# Altium schematic sheet Battery Management System.SchDoc("Battery Management System")
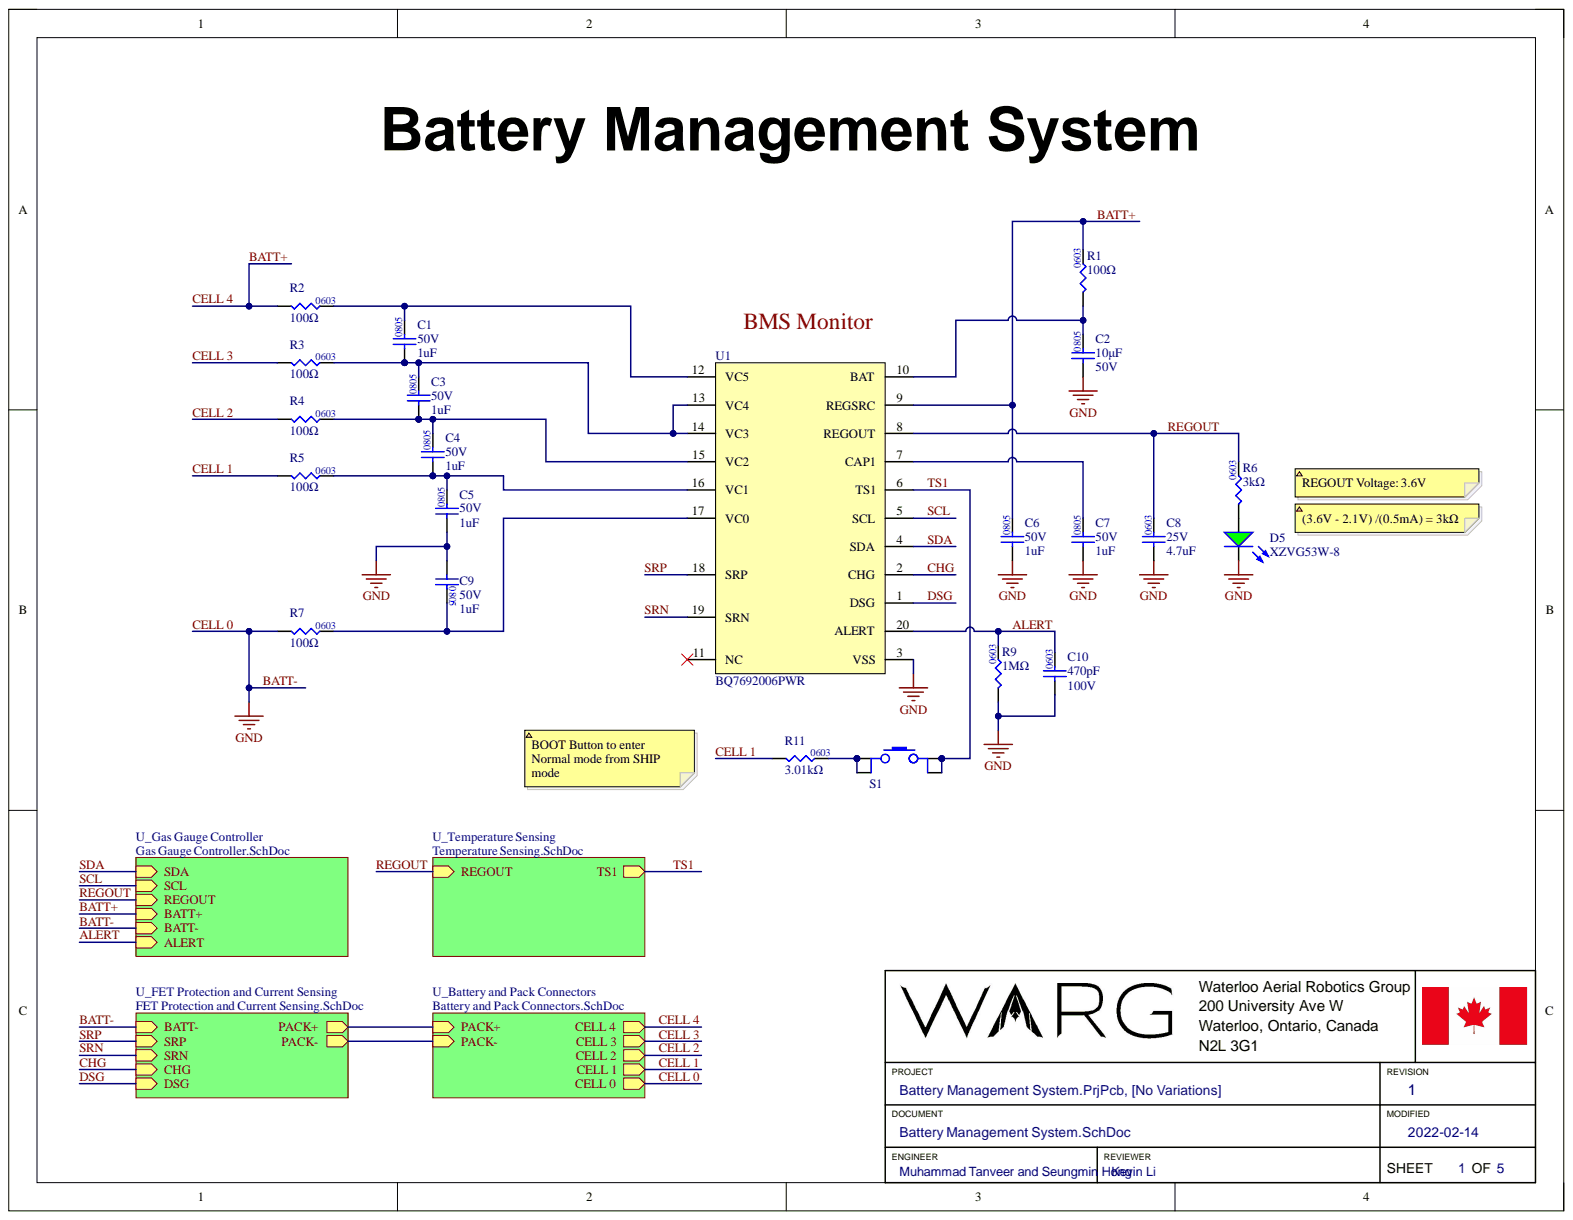
[diagram p0: Battery Management System.SchDoc]

## Parts
C1  value 1uF  Package: 0805  Voltage: 50V  [CAP0805]  (hidden comment: CAP_1uF_50V_0805)
C2  value 10μF  Package: 0805  Voltage: 50V  [CAP0805]  (hidden comment: CAP_10uF_50V_0805)
C3  value 1uF  Package: 0805  Voltage: 50V  [CAP0805]  (hidden comment: CAP_1uF_50V_0805)
C4  value 1uF  Package: 0805  Voltage: 50V  [CAP0805]  (hidden comment: CAP_1uF_50V_0805)
C5  value 1uF  Package: 0805  Voltage: 50V  [CAP0805]  (hidden comment: CAP_1uF_50V_0805)
C6  value 1uF  Package: 0805  Voltage: 50V  [CAP0805]  (hidden comment: CAP_1uF_50V_0805)
C7  value 1uF  Package: 0805  Voltage: 50V  [CAP0805]  (hidden comment: CAP_1uF_50V_0805)
C8  value 4.7uF  Package: 0603  Voltage: 25V  [CAP0603]  (hidden comment: CAP_4.7uF_25V_0603)
C9  value 1uF  Package: 0805  Voltage: 50V  [CAP0805]  (hidden comment: CAP_1uF_50V_0805)
C10  value 470pF  Package: 0603  Voltage Rating: 100V  [CAP0603]  (hidden comment: CAP_470pF_100V_0603)
D5  Manufacturer Part Number 1: XZVG53W-8  [LED0603]  (hidden comment: LED_GREEN_2.1V_0603)
R1  value 100Ω  Package: 0603  [RES0603]  (hidden comment: RES_0Ω_0603)
R2  value 100Ω  Package: 0603  [RES0603]  (hidden comment: RES_0Ω_0603)
R3  value 100Ω  Package: 0603  [RES0603]  (hidden comment: RES_0Ω_0603)
R4  value 100Ω  Package: 0603  [RES0603]  (hidden comment: RES_0Ω_0603)
R5  value 100Ω  Package: 0603  [RES0603]  (hidden comment: RES_0Ω_0603)
R6  Package: 0603  Resistance: 3kΩ  [RES0603]  (hidden comment: RES_3kΩ_0603_1%)
R7  value 100Ω  Package: 0603  [RES0603]  (hidden comment: RES_0Ω_0603)
R9  value 1MΩ  Package: 0603  [RES0603]  (hidden comment: RES_1MΩ_0603_1%)
R11  Package: 0603  Resistance: 3.01kΩ  [RES0603]  (hidden comment: RES_3.01kΩ_0603_1%)
S1  SWITCH_SPST_NO  [TL3301NF160QG/TR]  (value not drawn)
U1  BQ7692006PWR  [BQ7692006PWR]

## Nets
ALERT: C10.2 R9.2 U1.20(ALERT)
BATT+: C6.2 R1.2 R2.1 U1.9(REGSRC)
BATT-: C2.1 C5.1 C6.1 C7.1 C8.1 C9.1 C10.1 D5.2 R7.1 R9.1 U1.3(VSS)
CELL 1: R5.1 R11.1
CELL 2: R4.1
CELL 3: R3.1
CHG: U1.2(CHG)
DSG: U1.1(DSG)
REGOUT: C8.2 R6.2 U1.8(REGOUT)
SCL: U1.5(SCL)
SDA: U1.4(SDA)
SRN: U1.19(SRN)
SRP: U1.18(SRP)
TS1: S1.3 S1.4 U1.6(TS1)
NetC1_1: C1.1 C3.2 R3.2 U1.13(VC4) U1.14(VC3)
NetC1_2: C1.2 R2.2 U1.12(VC5)
NetC2_2: C2.2 R1.1 U1.10(BAT)
NetC3_1: C3.1 C4.2 R4.2 U1.15(VC2)
NetC4_1: C4.1 C5.2 R5.2 U1.16(VC1)
NetC7_2: C7.2 U1.7(CAP1)
NetC9_2: C9.2 R7.2 U1.17(VC0)
NetD5_1: D5.1 R6.1
NetR11_2: R11.2 S1.1 S1.2
NetU1_11: U1.11(NC)
PACK+:
PACK-:

## Library data of the parts
C1 C3-C7 C9 = CAP_1uF_50V_0805_MURATA: CAP CER 1UF 50V X7R ±10% 0805; Manufacturer 1: Murata Electronics; Manufacturer Part Number 1: GCJ21BR71H105KA01L; Component Kind: Standard; Mounting Type: Surface Mount; Supplier 1: Digi-Key; Supplier Part Number 1: 490-10667-1-ND; Temperature Coefficient: X7R; Tolerance: ±10%; Library Name: WARG.SchLib
C2 = CAP_10uF_50V_0805_MURATA: CAP CER 10UF 50V X5R ±10% 0805; Manufacturer 1: Murata Electronics; Manufacturer Part Number 1: GRM21BR61H106KE43L; Component Kind: Standard; LCSC Part #: C440198; Mounting Type: Surface Mount; Supplier 1: LCSC; Supplier 2: Digi-Key; Supplier Part Number 1: C440198; Supplier Part Number 2: 490-18663-1-ND; Temperature Coefficient: X5R; Tolerance: ±10%; Library Name: WARG.SchLib
C8 = CAP_4.7uF_25V_0603_MURATA: CAP CER 4.7UF 25V X6S ±10% 0603; Manufacturer 1: Murata Electronics; Manufacturer Part Number 1: GRM188C81E475KE11D; Component Kind: Standard; Mounting Type: Surface Mount; Supplier 1: Digi-Key; Supplier Part Number 1: 490-7199-1-ND; Temperature Coefficient: X6S; Tolerance: ±10%; Library Name: WARG.SchLib
C10 = CAP_470pF_100V_0603_MURATA: CAP CER 470PF 100V C0G/NP0 ±5% 0603; Manufacturer 1: Murata Electronics; Manufacturer Part Number 1: GCM1885C2A471JA16D; Component Kind: Standard; Mounting Type: Surface Mount; Supplier 1: Digi-Key; Supplier Part Number 1: 490-4949-1-ND; Temperature Coefficient: C0G/NP0; Tolerance: ±5%; Library Name: WARG.SchLib
D5 = LED_GREEN_2.1V_0603: LED GREEN 2.1V 0603; Manufacturer 1: SunLED; Color: Green; Component Kind: Standard; Forward Voltage: 2.1V; Mounting Type: Surface Mount; Package: 0603; Supplier 1: Digi-Key; Supplier Part Number 1: 1497-1215-1-ND; Library Name: WARG.SchLib
R1-R5 R7 = RES_100Ω_0603: RES 100 OHM 5% 1/10W 0603; Manufacturer 1: YAGEO; Manufacturer Part Number 1: RC0603JR-13100RL; Component Kind: Standard; Mounting Type: Surface Mount; Power Rating: 1/10W; Supplier 1: Digi-Key; Supplier Part Number 1: 13-RC0603JR-13100RLCT-ND; Library Name: WARG.SchLib
R6 = RES_3kΩ_0603_1%: RES 3K OHM 1% 1/10W 0603; Manufacturer 1: YAGEO; Manufacturer Part Number 1: RC0603FR-073KL; Component Kind: Standard; Mounting Type: Surface Mount; Power Rating: 1/10W; Supplier 1: Digi-Key; Supplier Part Number 1: 311-3.00KHRCT-ND; Tolerance: ±1%; Library Name: WARG.SchLib
R9 = RES_1MΩ_0603_5%: RES 1M OHM 5% 1/10W 0603; Manufacturer 1: Stackpole Electronics Inc; Manufacturer Part Number 1: RMCF0603JT1M00; Component Kind: Standard; Mounting Type: Surface Mount; Power Rating: 1/10W; Supplier 1: Digi-Key; Supplier Part Number 1: RMCF0603JT1M00CT-ND; Tolerance: ±5%; Library Name: WARG.SchLib
R11 = RES_3.01kΩ_0603_1%: RES 3.01K OHM 1% 1/10W 0603; Manufacturer 1: YAGEO; Manufacturer Part Number 1: RC0603FR-073K01L; Component Kind: Standard; Mounting Type: Surface Mount; Power Rating: 1/10W; Supplier 1: Digi-Key; Supplier Part Number 1: 311-3.01KHRCT-ND; Tolerance: ±1%; Library Name: WARG.SchLib
S1 = SWITCH_SPST_NO: SWITCH TACTILE SPST-NO 0.05A 12V; Manufacturer 1: E-Switch; Circuit: SPST-NO; Component Kind: Standard; Manufacturer Part Number 1: TL3301NF160QG/TR; Mounting Type: Surface Mount; Supplier 1: Digi-Key; Supplier Part Number 1: EG1867CT-ND; Library Name: WARG.SchLib
U1 = BQ7692006PWR: IC BATT MGMT LI-ION AFE 20TSSOP; Manufacturer 1: Texas Instruments; Component Kind: Standard; Manufacturer Part Number 1: BQ7692006PWR; Mounting Type: Surface Mount; Supplier 1: Digi-Key; Supplier 2: LCSC; Supplier Part Number 1: 296-39960-1-ND; Library Name: WARG.SchLib
